# Altium schematic sheet Sheet2.SchDoc(Sheet2)
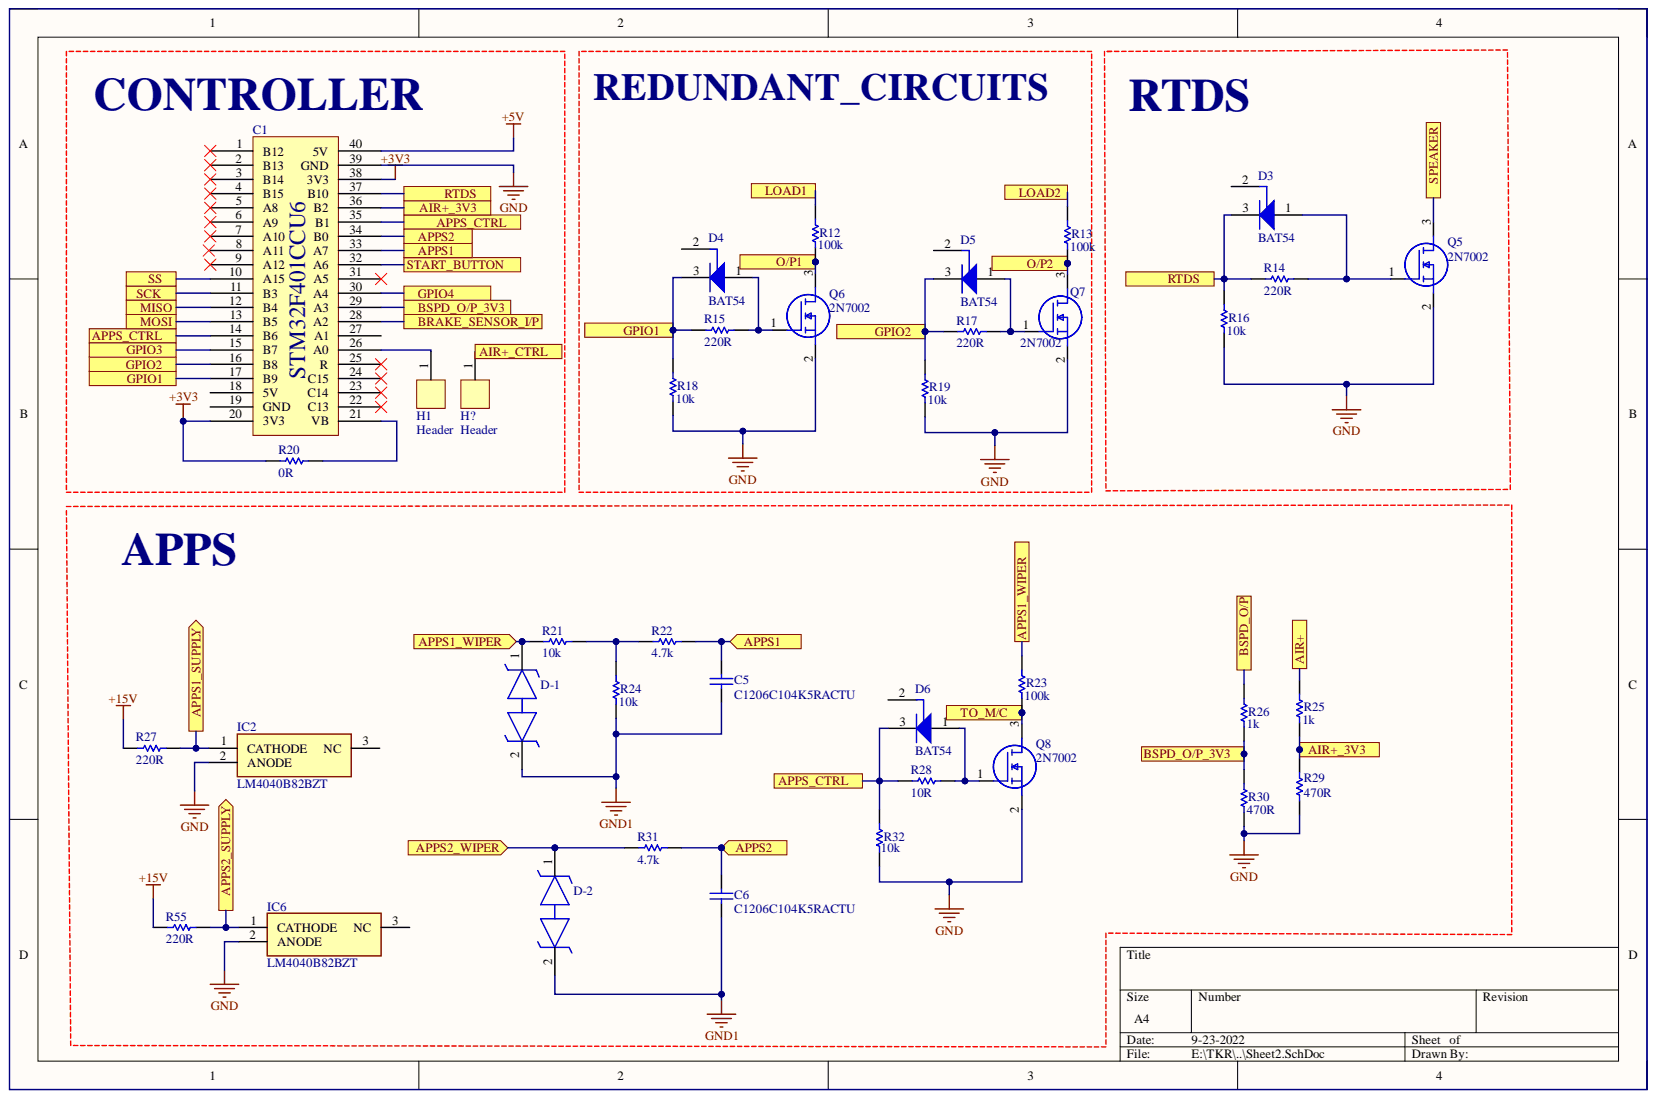
[diagram p0: Sheet2.SchDoc]

## Parts
C1  Component_1  [PCBComponent_1]  (value not drawn)
C5  C1206C104K5RACTU  [KEMT-1206-2_N]
C6  C1206C104K5RACTU  [KEMT-1206-2_N]
D3  BAT54  [INF-SOT23_N]
D4  BAT54  [INF-SOT23_N]
D5  BAT54  [INF-SOT23_N]
D6  BAT54  [INF-SOT23_N]
D-1  TPD1E6B06DPLR  [R1206]  (value not drawn)
D-2  TPD1E6B06DPLR  [R1206]  (value not drawn)
H1  Header  [Header]
H?  Header  [Header]
IC2  LM4040B82BZT  [SOT95P237X112-3N]
IC6  LM4040B82BZT  [SOT95P237X112-3N]
Q5  2N7002  [SOT96P240X120-3N]
Q6  2N7002  [SOT96P240X120-3N]
Q7  2N7002  [SOT96P240X120-3N]
Q8  2N7002  [SOT96P240X120-3N]
R12  100k  [RESC3216X60X45NL10T20 - duplicate]
R13  100k  [RESC3216X60X45NL10T20 - duplicate]
R14  220R  [RESC3216X60X45NL10T20 - duplicate]
R15  220R  [RESC3216X60X45NL10T20 - duplicate]
R16  10k  [RESC3216X60X45NL10T20 - duplicate]
R17  220R  [RESC3216X60X45NL10T20 - duplicate]
R18  10k  [RESC3216X60X45NL10T20 - duplicate]
R19  10k  [RESC3216X60X45NL10T20 - duplicate]
R20  0R  [R1206]
R21  10k  [RESC3216X60X45NL10T20 - duplicate]
R22  4.7k  [RESC3216X60X45NL10T20 - duplicate]
R23  100k  [RESC3216X60X45NL10T20 - duplicate]
R24  10k  [RESC3216X60X45NL10T20 - duplicate]
R25  1k  [RESC3216X60X45NL10T20 - duplicate]
R26  1k  [RESC3216X60X45NL10T20 - duplicate]
R27  220R  [RESC3216X60X45NL10T20 - duplicate]
R28  10R  [RESC3216X60X45NL10T20 - duplicate]
R29  470R  [R1206]
R30  470R  [RESC3216X60X45NL10T20 - duplicate]
R31  4.7k  [RESC3216X60X45NL10T20 - duplicate]
R32  10k  [RESC3216X60X45NL10T20 - duplicate]
R55  220R  [RESC3216X60X45NL10T20 - duplicate]

## Ports
AIR+, AIR+_3V3, AIR+_CTRL, APPS1, APPS1_SUPPLY, APPS1_WIPER, APPS2, APPS2_SUPPLY, APPS2_WIPER, APPS_CTRL, BRAKE_SENSOR_I/P, BSPD_O/P, BSPD_O/P_3V3, GPIO1, GPIO2, GPIO3, GPIO4, LOAD1, LOAD2, MISO, MOSI, O/P1, O/P2, RTDS, SCK, SPEAKER, SS, START_BUTTON, TO_M/C

## Nets
+3V3: C1.20(3V3) C1.38(3V3) R20.1
+5V: C1.40(5V)
+15V: R27.2 R55.2
GND: C1.39(GND) IC2.2 IC6.2 Q5.2 Q6.2 Q7.2 Q8.2 R16.1 R18.1 R19.1 R29.1 R30.1 R32.1
GND1: C5.1 C6.1 D-1.2 D-2.2 R24.1
AIR+: R25.2 ; port AIR+
AIR+_3V3: C1.36(B2) R25.1 R29.2 ; port AIR+_3V3
AIR+_CTRL: H?.1 ; port AIR+_CTRL
APPS1: C1.33(A7) C5.2 R22.1 ; port APPS1
APPS1_SUPPLY: IC2.1 R27.1 ; port APPS1_SUPPLY
APPS1_WIPER: D-1.1 R21.2 R23.2 ; port APPS1_WIPER
APPS2: C1.34(B0) C6.2 R31.1 ; port APPS2
APPS2_SUPPLY: IC6.1 R55.1 ; port APPS2_SUPPLY
APPS2_WIPER: D-2.1 R31.2 ; port APPS2_WIPER
APPS_CTRL: C1.14(B6) C1.35(B1) D6.3 R28.2 R32.2 ; port APPS_CTRL
BRAKE_SENSOR_I/P: C1.28(A2) ; port BRAKE_SENSOR_I/P
BSPD_O/P: R26.2 ; port BSPD_O/P
BSPD_O/P_3V3: C1.29(A3) R26.1 R30.2 ; port BSPD_O/P_3V3
GPIO1: C1.17(B9) D4.3 R15.2 R18.2 ; port GPIO1
GPIO2: C1.16(B8) D5.3 R17.2 R19.2 ; port GPIO2
GPIO3: C1.15(B7) ; port GPIO3
GPIO4: C1.30(A4) ; port GPIO4
LOAD1: R12.2 ; port LOAD1
LOAD2: R13.2 ; port LOAD2
MISO: C1.12(B4) ; port MISO
MOSI: C1.13(B5) ; port MOSI
O/P1: Q6.3 R12.1 ; port O/P1
O/P2: Q7.3 R13.1 ; port O/P2
RTDS: C1.37(B10) D3.3 R14.2 R16.2 ; port RTDS
SCK: C1.11(B3) ; port SCK
SPEAKER: Q5.3 ; port SPEAKER
SS: C1.10(A15) ; port SS
START_BUTTON: C1.32(A6) ; port START_BUTTON
TO_M/C: Q8.3 R23.1 ; port TO_M/C
NetC1_21: C1.21(VB) R20.2
NetC1_26: C1.26(A0) H1.1
NetD3_1: D3.1 Q5.1 R14.1
NetD4_1: D4.1 Q6.1 R15.1
NetD5_1: D5.1 Q7.1 R17.1
NetD6_1: D6.1 Q8.1 R28.1
NetR21_1: R21.1 R22.2 R24.2

Not connected: C1 1(B12) 2(B13) 3(B14) 4(B15) 5(A8) 6(A9) 7(A10) 8(A11) 9(A12) 18(5V) 19(GND) 22(C13) 23(C14) 24(C15) 25(R) 27(A1) 31(A5); D3 2; D4 2; D5 2; D6 2; IC2 3; IC6 3

## Library data of the parts
C5 C6 = CMP-1037-04202-2: CAP, Ceramic, 0.1 uF, +/- 10%, 50 V, -55 to 125 degC, 1206 (3216 Metric), RoHS, Tape and Reel; Manufacturer: Kemet; Capacitance: 0.1µF; Capacitor Case Style: 1206[3216Metric]; Dielectric Characteristic: X7R; Product Range: KEMET-CSeries; SVHC: ToBeAdvised; Voltage Rating: 50V; Capacitance Tolerance: ±10%; Component Kind: Standard; Datasheet URL: https://datasheet.ciiva.com/6125/1725438-6125494.pdf; Datasheet Version: Jul-13; Library Name: Schlib1.SchLib; Manufacturer URL: http://www.kemet.com/; Operating Temperature Max: 125degC; Operating Temperature Min: -55degC; Package Description: 2-Leads SMD, Body 3.2 x 1.6 mm; Package Reference: 1206-2; Packaging: Tape&Reel
D3-D6 = CMP-1182-00010-1: Silicon Schottky Diode, SOT23, Reel, Green; Manufacturer: Infineon; Configuration: Single; CT (max): 10Â pF; Green: TRUE; IF (max): 200Â mA; VF (max): 800Â mV; VR (max): 30Â V; Component Kind: Standard; ComponentLink1Description: Manufacturer URL; ComponentLink1URL: http://www.infineon.com/; ComponentLink2Description: Datasheet; ComponentLink2URL: http://www.infineon.com/dgdl/bat54series.pdf?folderId=db3a30431400ef68011425f1ca2505e3&fileId=db3a304314dca3890115190b74210ea6; ComponentLink3Description: Package Specification; ComponentLink3URL: http://www.infineon.com/dgdl/SOT23-Package_Overview.pdf?folderId=db3a30431936bc4b0119532290053c66&fileId=db3a30431936bc4b0119533468123c85; Library Name: Schlib1.SchLib; Mounting Technology: Surface Mount; PackageDescription: 3-Pin Small Outline Transistor Package, 1.3 x 2.9 mm Body, 0.95 mm Pitch; PackageReference: SOT23; PackageVersion: Apr-08; Packing: Reel; PartNumber: BAT54
IC2 IC6 = LM4040B25IDBZT: Integrated Circuit; Manufacturer_Name: Texas Instruments; Arrow Part Number: LM4040B25IDBZT; Arrow Price/Stock: https://www.arrow.com/en/products/lm4040b25idbzt/texas-instruments; Component Kind: Standard; Datasheet Link: https://www.ti.com/lit/gpn/LM4040; Height: 1.12mm; Manufacturer_Part_Number: LM4040B25IDBZT; Mouser Part Number: 595-LM4040B25IDBZT; Mouser Price/Stock: https://www.mouser.com/Search/Refine.aspx?Keyword=595-LM4040B25IDBZT
Q5-Q8 = 2N7002: MOSFET (N-Channel); Manufacturer_Name: Vishay; Component Kind: Standard; Datasheet Link: https://www.diodes.com/assets/Datasheets/ds11303.pdf; Height: 1.2mm; Manufacturer_Part_Number: 2N7002; Mouser Part Number: 781-2N7002; Mouser Price/Stock: https://www.mouser.co.uk/ProductDetail/Vishay-Siliconix/2N7002?qs=xBpwZ0JX2zKeuvZKtdYLZQ%3D%3D
R12-R19 R21-R28 R30-R32 R55 = CMP-2003-02213-1: Manufacturer: Vishay Dale; Part Number: CRCW120647K0FKEA; Component Kind: Standard; Library Name: Schlib1.SchLib
R20 R29 = RES: Manufacturer: Vishay Dale; Part Number: CRCW120647K0FKEA; Component Kind: Standard; Library Name: Schlib1.SchLib
C1 = Component_1: Component Kind: Standard; Library Name: Schlib1.SchLib
D-1 D-2 = TPD1E6B06DPLR: Component Kind: Standard; Library Name: Diode_Texas_Instruments_TPD1E6B06DPLR_eec.SchLib
H1 H? = Header: Component Kind: Standard; Library Name: Schlib1.SchLib
